# Altium schematic sheet EFUSE_AND_REGULATOR.SchDoc
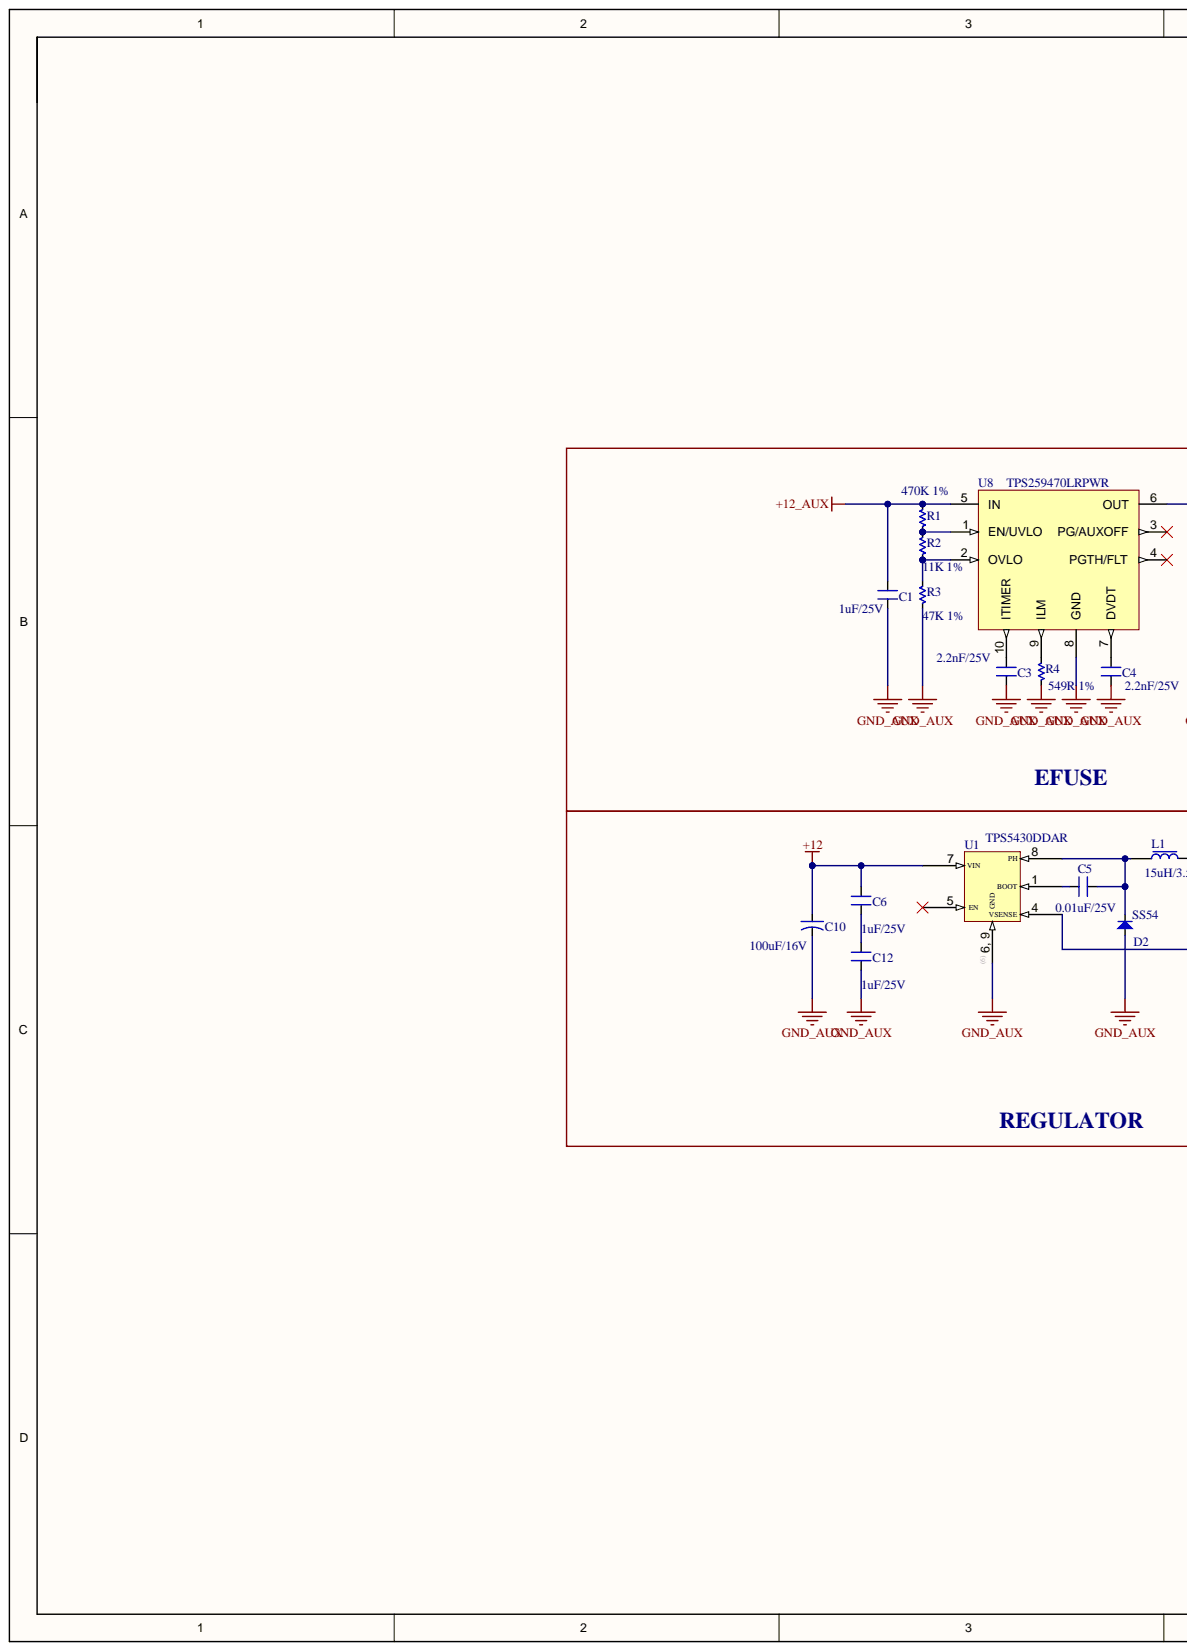
[diagram p0: EFUSE_AND_REGULATOR.SchDoc, left]
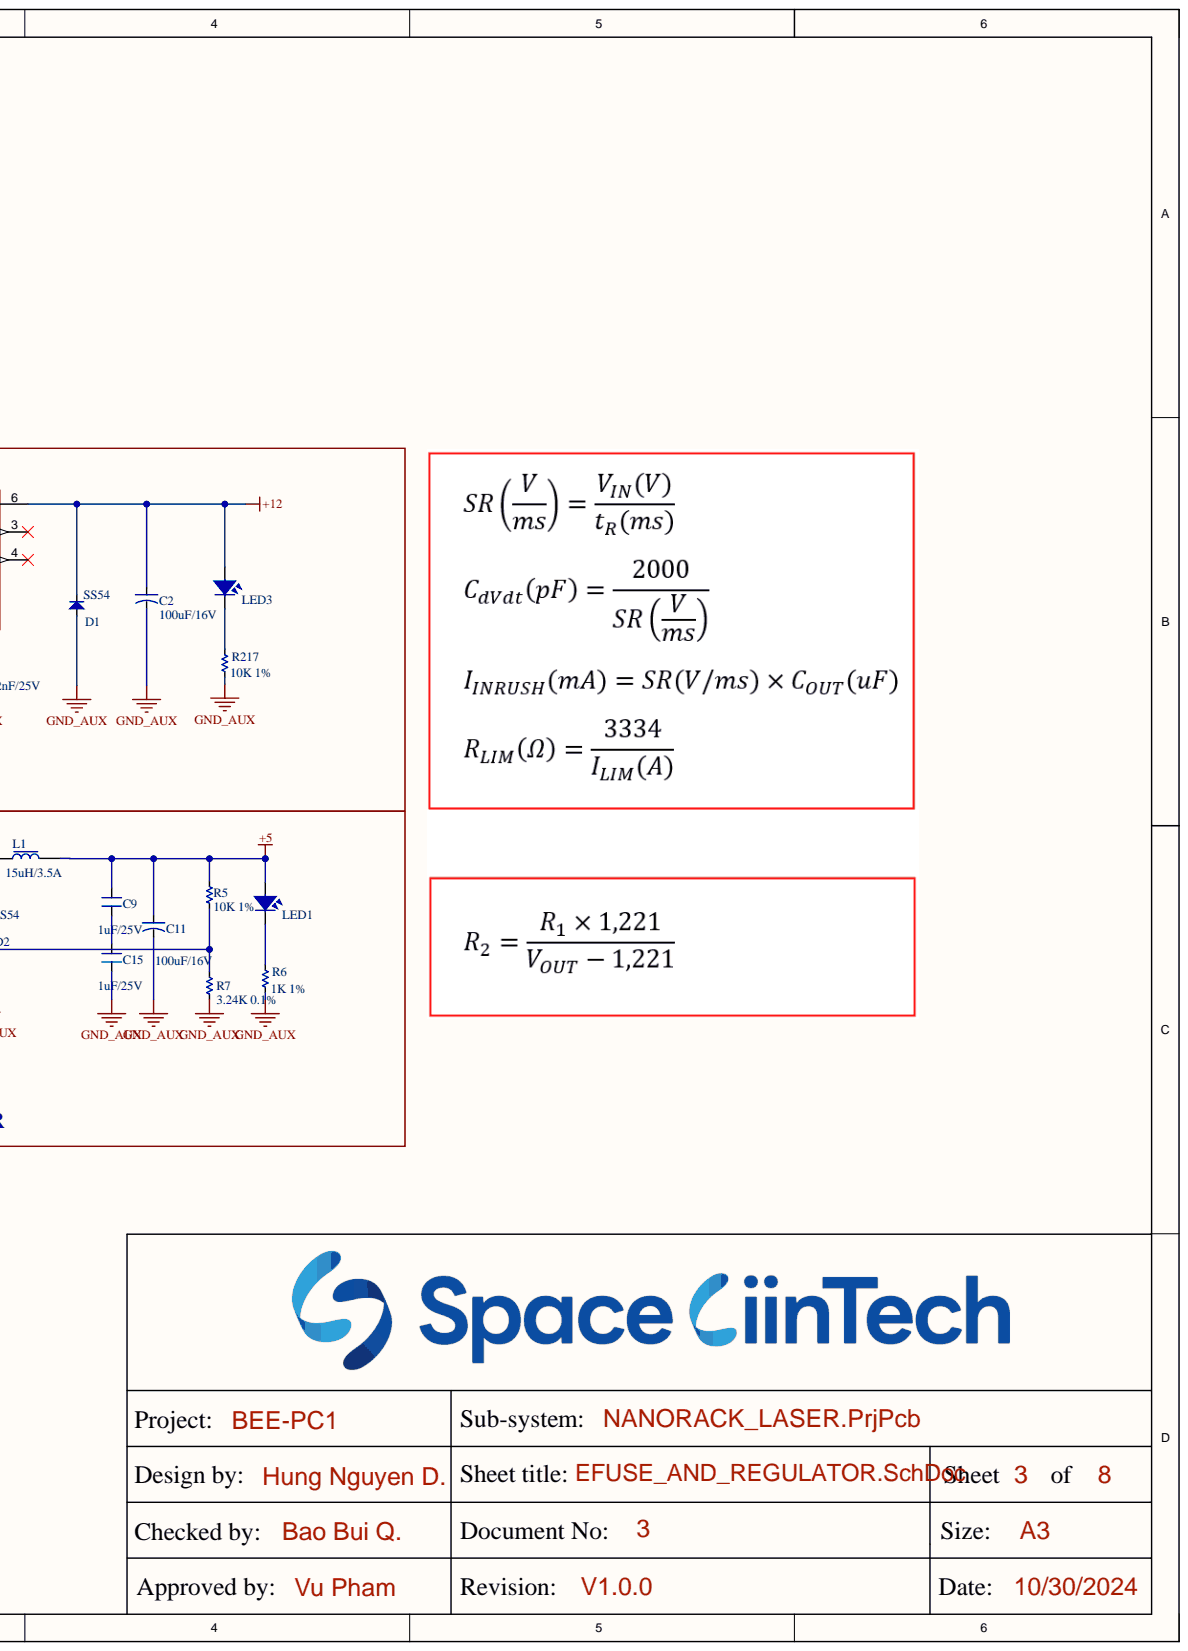
[diagram p1: EFUSE_AND_REGULATOR.SchDoc, right]

## Parts
C1  1uF/25V  [SM-0603]
C2  100uF/16V  [TANT 2312]
C3  2.2nF/25V  [SM-0603]
C4  2.2nF/25V  [SM-0603]
C5  0.01uF/25V  [SM-0603]
C6  1uF/25V  [SM-0603]
C9  1uF/25V  [SM-0603]
C10  100uF/16V  [TANT 2312]
C11  100uF/16V  [TANT 2312]
C12  1uF/25V  [SM-0603]
C15  1uF/25V  [SM-0603]
D1  SS54  [DIO-SMD-A]
D2  SS54  [DIO-SMD-A]
L1  15uH/3.5A  [SRP7050TA]
LED1  LED  [REDLED_0603]
LED3  LED  [REDLED_0603]
R1  470K 1%  [RES-SMD-0603]
R2  11K 1%  [RES-SMD-0603]
R3  47K 1%  [RES-SMD-0603]
R4  549R 1%  [RES-SMD-0603]
R5  10K 1%  [RES-SMD-0603]
R6  1K 1%  [RES-SMD-0603]
R7  3.24K 0.1%  [RES-SMD-0603]
R217  10K 1%  [RES-SMD-0603]
U1  TPS5430DDAR  [HSOP8]
U8  TPS259470LRPWR  [TPS259470]

## Nets
+5: C9.1 C11.1 L1.2 LED1.1 R5.2
+12: C2.1 C6.1 C10.1 D1.2 LED3.1 U1.7(VIN) U8.6(OUT)
+12_AUX: C1.2 R1.2 U8.5(IN)
GND_AUX: C1.1 C2.2 C3.1 C4.1 C10.2 C11.2 C12.2 C15.2 D1.1 D2.1 R3.1 R4.1 R6.2 R7.1 R217.2 U1.6(GND) U8.8(GND)
NetC3_2: C3.2 U8.10(ITIMER)
NetC4_2: C4.2 U8.7(DVDT)
NetC5_1: C5.1 D2.2 L1.1 U1.8(PH)
NetC5_2: C5.2 U1.1(BOOT)
NetC6_2: C6.2 C12.1
NetC9_2: C9.2 C15.1
NetLED1_2: LED1.2 R6.1
NetLED3_2: LED3.2 R217.1
NetR1_1: R1.1 R2.2 U8.1(EN/UVLO)
NetR2_1: R2.1 R3.2 U8.2(OVLO)
NetR4_2: R4.2 U8.9(ILM)
NetR5_1: R5.1 R7.2 U1.4(VSENSE)

Not connected: U1 5(EN); U8 3(PG/AUXOFF) 4(PGTH/FLT)

## Library data of the parts
C1 C3-C6 C9 C12 C15 = CM: 0603; Value: 103; Component Kind: Standard; Library Name: Capacitors.SchLib
C2 C10 C11 = CN_TANT: Component Kind: Standard; Library Name: Library.SchLib
D1 D2 = Diode SMD: DIODE SCHOTTKY 40V 5A SMA; Code - JEDEC: DO-214-AB; Value: 1N5007; Component Kind: Standard; Library Name: Library.SchLib; Published: 8-Jun-2000; Revision: July-2002: Re-released for DXP Platform.; Publisher: Altium Limited
L1 = INDUCTOR: SRP7050TA-150M; Component Kind: Standard; Library Name: Library.SchLib
LED1 LED3 = LED SMD: Value: LED 3 - 5 ly 2 Colour; Component Kind: Standard; Library Name: Library.SchLib
R1-R6 R217 = Res-SMD: 0603; Manufacturer: Generic Components; Class: Passive; Component Kind: Standard; Library Name: Library.SchLib; Published: 08/06/2000; Publisher: Altium Hobart Technology Centre
R7 = Res-SMD: ERA-3AEB3241V; Manufacturer: Generic Components; Class: Passive; Component Kind: Standard; Library Name: Library.SchLib; Published: 08/06/2000; Publisher: Altium Hobart Technology Centre
U1 = TPS543X: 8SOP; Component Kind: Standard; Library Name: Library.SchLib
U8 = TPS259470: P/N: TPS259470LRPWR; Component Kind: Standard; Library Name: Library.SchLib
